# Altium schematic sheet MCU.SchDoc(MCU)
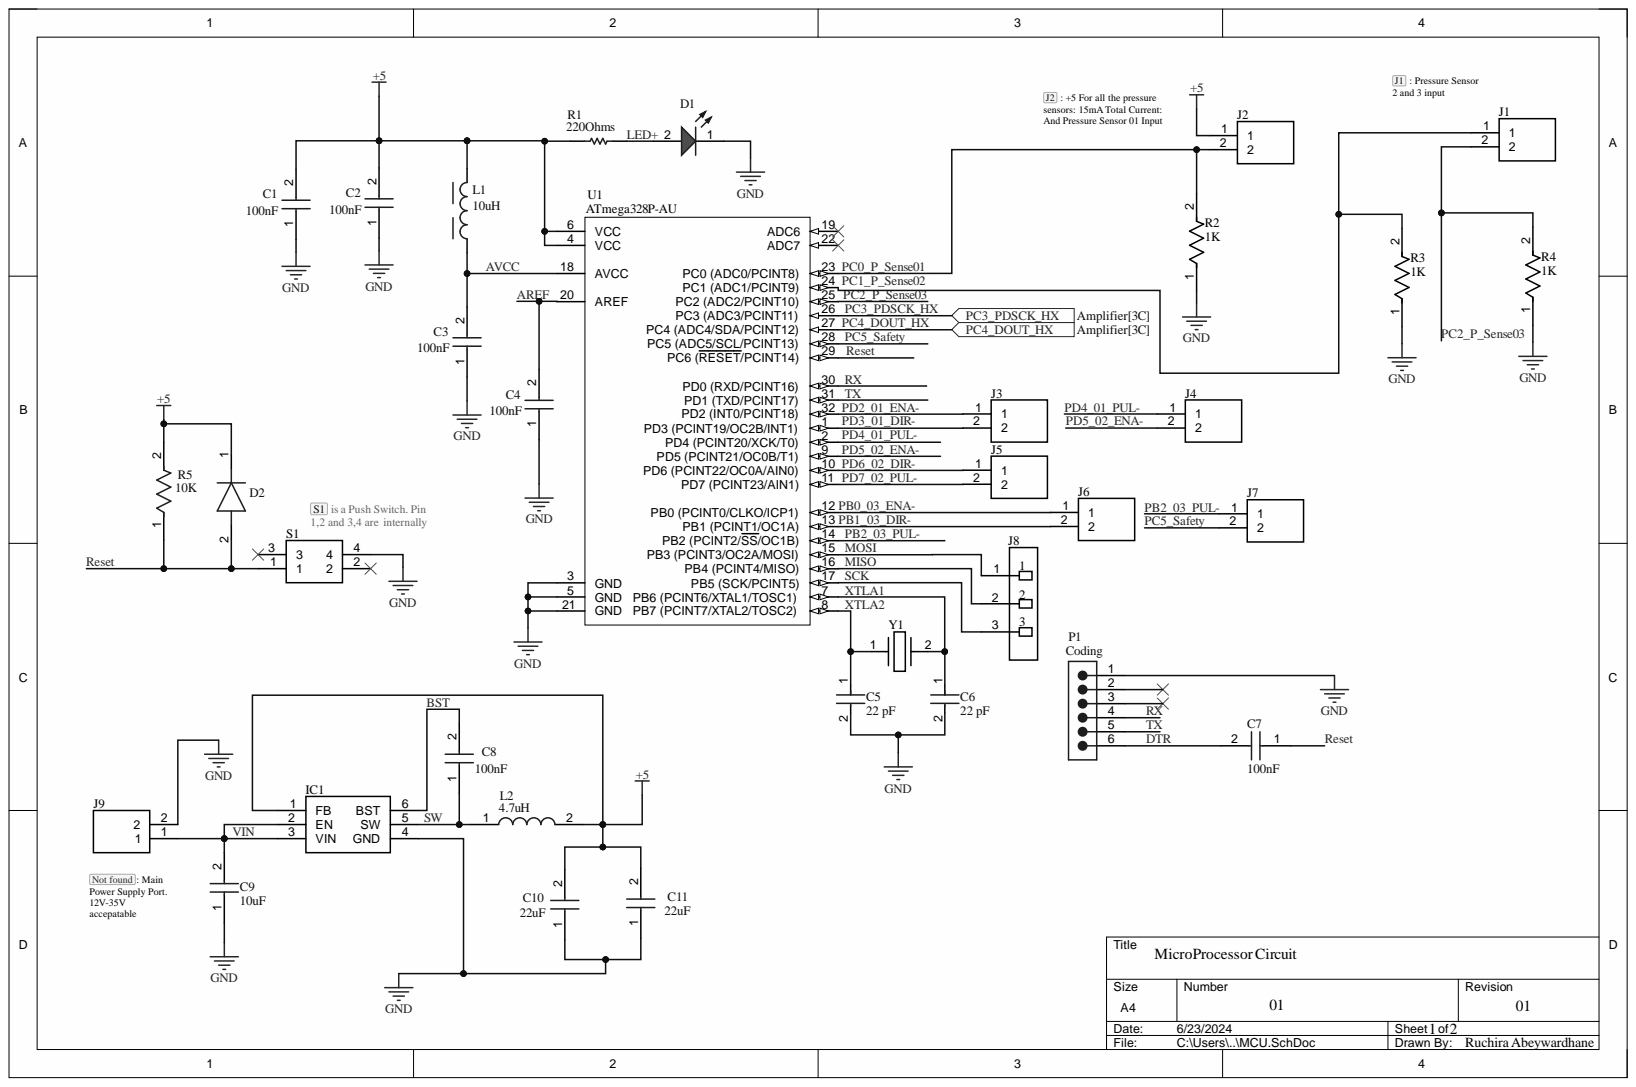
[diagram p0: MCU.SchDoc]

## Parts
C1  value 100nF  [C0805]  (hidden comment: C0805Y104J3RACTU)
C2  value 100nF  [C0805]  (hidden comment: C0805Y104J3RACTU)
C3  value 100nF  [C0805]  (hidden comment: C0805Y104J3RACTU)
C4  value 100nF  [C0805]  (hidden comment: C0805Y104J3RACTU)
C5  value 22 pF  Capacitance: 22 pF  [FP-C0805C-DN-MFG]  (hidden comment: C0805C220F1HACTU)
C6  value 22 pF  Capacitance: 22 pF  [FP-C0805C-DN-MFG]  (hidden comment: C0805C220F1HACTU)
C7  value 100nF  [C0805]  (hidden comment: C0805Y104J3RACTU)
C8  value 100nF  [C0805]  (hidden comment: C0805Y104J3RACTU)
C9  value 10uF  [C0805]  (hidden comment: C0805C106K4PAC7210)
C10  value 22uF  [C0805]  (hidden comment: C0805C226K9PACTU)
C11  value 22uF  [C0805]  (hidden comment: C0805C226K9PACTU)
D1  150080RS75000  [WL-SMCW_0805_150080xx75000]  (value not drawn)
D2  CDSU4148  [DIOM179X85N]  (value not drawn)
IC1  AP63205WU-7  [AP63205WU7]  (value not drawn)
J1  1727010  [1727010]  (value not drawn)
J2  1727010  [1727010]  (value not drawn)
J3  1727010  [1727010]  (value not drawn)
J4  1727010  [1727010]  (value not drawn)
J5  1727010  [1727010]  (value not drawn)
J6  1727010  [1727010]  (value not drawn)
J7  1727010  [1727010]  (value not drawn)
J8  TMM-103-03-T-S  [FP-TMM-103-03-T-S-MFG]  (value not drawn)
J9  1727010  [1727010]  (value not drawn)
L1  value 10uH  [WE-GFH_3225]  (hidden comment: 744764910)
L2  value 4.7uH  [INDPM7366X300N]  (hidden comment: SRP7028CC-4R7M)
P1  value Coding  [SMTC-TSW-106-07-X-S]  (hidden comment: TSW-106-07-L-S)
R1  value 220Ohms  [RESC2013X60X30ML20T20]  (hidden comment: RK73H2ATTD2200F)
R2  value 1K  [FP-RK73H2A-IPC_C]  (hidden comment: RK73H2ATTD1001D)
R3  value 1K  [FP-RK73H2A-IPC_C]  (hidden comment: RK73H2ATTD1001D)
R4  value 1K  [FP-RK73H2A-IPC_C]  (hidden comment: RK73H2ATTD1001D)
R5  value 10K  [FP-RK73H2A-IPC_C]  (hidden comment: RK73H2ATTED1002F)
S1  TL3305BF160QG  [TL3305BF160QG]  (value not drawn)
U1  ATmega328P-AU  [32A_M]
Y1  ABLS-16.000MHz-16-A-4-H-T  [ABLS]  (value not drawn)

## Ports
PC3_PDSCK_HX, PC4_DOUT_HX

## Nets
+5: C1.2 C2.2 C10.2 C11.2 D2.1 IC1.1(FB) J2.1 L1.2 L2.2 R1.1 R5.2 U1.4(VCC) U1.6(VCC)
GND: C1.1 C2.1 C3.1 C4.1 C5.2 C6.2 C9.1 C10.1 C11.1 D1.1 IC1.4(GND) J9.2 P1.1 R2.1 R3.1 R4.1 S1.4 U1.3(GND) U1.5(GND) U1.21(GND)
AREF: C4.2 U1.20(AREF)
AVCC: C3.2 L1.1 U1.18(AVCC)
BST: C8.2 IC1.6(BST)
DTR: C7.2 P1.6
LED+: D1.2 R1.2
MISO: J8.2 U1.16(PB4_(PCINT4/MISO))
MOSI: J8.1 U1.15(PB3_(PCINT3/OC2A/MOSI))
PB0_03_ENA-: J6.1 U1.12(PB0_(PCINT0/CLKO/ICP1))
PB1_03_DIR-: J6.2 U1.13(PB1_(PCINT1/OC1A))
PB2_03_PUL-: J7.1 U1.14(PB2_(PCINT2/SS/OC1B))
PC0_P_Sense01: J2.2 R2.2 U1.23
PC2_P_Sense03: J1.2 R4.2 U1.25
PC3_PDSCK_HX: U1.26(PC3_(ADC3/PCINT11)) ; port PC3_PDSCK_HX
PC4_DOUT_HX: U1.27(PC4_(ADC4/SDA/PCINT12)) ; port PC4_DOUT_HX
PC5_Safety: J7.2 U1.28(PC5_(ADC5/SCL/PCINT13))
PD2_01_ENA-: J3.1 U1.32(PD2_(INT0/PCINT18))
PD3_01_DIR-: J3.2 U1.1(PD3_(PCINT19/OC2B/INT1))
PD4_01_PUL-: J4.1 U1.2(PD4_(PCINT20/XCK/T0))
PD5_02_ENA-: J4.2 U1.9(PD5_(PCINT21/OC0B/T1))
PD6_02_DIR-: J5.1 U1.10(PD6_(PCINT22/OC0A/AIN0))
PD7_02_PUL-: J5.2 U1.11(PD7_(PCINT23/AIN1))
Reset: C7.1 D2.2 R5.1 S1.1 U1.29(PC6_(RESET/PCINT14))
RX: P1.4 U1.30(PD0_(RXD/PCINT16))
SCK: J8.3 U1.17(PB5_(SCK/PCINT5))
SW: C8.1 IC1.5(SW) L2.1
TX: P1.5 U1.31(PD1_(TXD/PCINT17))
VIN: C9.2 IC1.2(EN) IC1.3(VIN) J9.1
XTLA1: C6.1 U1.7 Y1.2
XTLA2: C5.1 U1.8 Y1.1
NetJ1_1: J1.1 R3.2 U1.24(PC1_(ADC1/PCINT9))
PC1_P_Sense02:

Not connected: P1 2 3; S1 2 3; U1 19(ADC6) 22(ADC7)

## Library data of the parts
C1-C4 C7 C8 = C0805Y104J3RACTU: Capacitor; Manufacturer_Name: KEMET; Component Kind: Standard; Datasheet Link: https://content.kemet.com/datasheets/KEM_C1015_X7R_FF-CAP_SMD.pdf; Height: 1.1mm; Manufacturer_Part_Number: C0805Y104J3RACTU
C5 C6 = CMP-03020-009085-1: C0805 22 pF X8R 30ppm/°C 1.00% 100 V; Manufacturer: KEMET; Material: Ceramic; Tolerance: 0.01; Voltage Rating: 100 V; Automotive: No; Category: Cap; Component Kind: Standard; ComponentLink2Description: Datasheet; ComponentLink2URL: https://content.kemet.com/datasheets/KEM_C1003_C0G_SMD.pdf; Device Class L1: Passive Components; Device Class L2: Capacitors; Device Class L3: Ceramic Capacitors; Height: 0.88mm; Ipc Land Pattern Name: CAPC200125X78; Lead Free: Yes; Library Name: Altium Content Vault; Manufacturer Part Number: C0805C220F1HACTU; Octopart: https://octopart.com/c0805c220f1hactu-kemet-88726806; Package: C0805C-DN; RoHS: TRUE; Temperature: -55°C to +125°C; Temperature Characteristic: X8R; Temperature Coefficient: 30ppm/°C; Temperature Range High: +125°C; Temperature Range Low: -55°C
C9 = C0805C106K4PAC7210: Capacitor; Manufacturer_Name: KEMET; Arrow Part Number: C0805C106K4PAC7210; Arrow Price/Stock: https://www.arrow.com/en/products/c0805c106k4pac7210/kemet-corporation; Component Kind: Standard; Datasheet Link: https://content.kemet.com/datasheets/KEM_C1006_X5R_SMD.pdf; Height: 1.1mm; Manufacturer_Part_Number: C0805C106K4PAC7210; Mouser Part Number: 80-C0805C106K4P7210; Mouser Price/Stock: https://www.mouser.co.uk/ProductDetail/KEMET/C0805C106K4PAC7210?qs=zDkSFN9STR9jrJZBgSwCDA%3D%3D
C10 C11 = C0805C226K9PACTU: Capacitor; Manufacturer_Name: KEMET; Arrow Part Number: C0805C226K9PACTU; Arrow Price/Stock: https://www.arrow.com/en/products/c0805c226k9pactu/kemet-corporation; Component Kind: Standard; Datasheet Link: https://content.kemet.com/datasheets/KEM_C1006_X5R_SMD.pdf; Height: 1.1mm; Manufacturer_Part_Number: C0805C226K9PACTU; Mouser Part Number: 80-C0805C226K9PACTU; Mouser Price/Stock: https://www.mouser.co.uk/ProductDetail/KEMET/C0805C226K9PACTU?qs=CmJlwSR1kv6i2XEByn7wWw%3D%3D
D1 = CMP-1426-00011-2: NexarPartId: 29717781; Color: Red; Dominant Wavelength: 625nm; Forward Current: 100mA; Forward Voltage: 2V; Lens Style: Clear, Rectangular; Luminous Intensity: 150mcd; Max Reverse Voltage (DC): 5V; Peak Wavelength: 630nm; Test Current: 20mA; Viewing Angle: 140°; Case Code (Imperial): 0805; Case Code (Metric): 2012; Case/Package: 0805; Component Kind: Standard; Height: 700µm; Length: 2mm; Library Name: Altium Content Vault; Max Operating Temperature: 85°C; Min Operating Temperature: -40°C; Mount: Surface Mount; Packaging: Tape and Reel; REACH SVHC: Unknown; RoHS: Compliant; Wavelength: 625nm; Width: 1.25mm
D2 = CDSU4148: Diode; Manufacturer_Name: Comchip Technology; Arrow Part Number: CDSU4148; Arrow Price/Stock: https://www.arrow.com/en/products/cdsu4148/comchip-technology?region=nac; Component Kind: Standard; Datasheet Link: https://datasheet.datasheetarchive.com/originals/distributors/Datasheets-7/DSA-139250.pdf; Height: 0.85mm; Manufacturer_Part_Number: CDSU4148; Mouser Part Number: 750-CDSU4148; Mouser Price/Stock: https://www.mouser.co.uk/ProductDetail/Comchip-Technology/CDSU4148?qs=tw%252BuQ%2FB6PO1A4Pq3N4jPEA%3D%3D
IC1 = AP63205WU-7: Integrated Circuit; Manufacturer_Name: Diodes Incorporated; Arrow Part Number: AP63205WU-7; Arrow Price/Stock: https://www.arrow.com/en/products/ap63205wu-7/diodes-incorporated?region=europe; Component Kind: Standard; Datasheet Link: https://www.diodes.com//assets/Datasheets/AP63200-AP63201-AP63203-AP63205.pdf; Height: 1mm; Library Name: SamacSys.SchLib; Manufacturer_Part_Number: AP63205WU-7; Mouser Part Number: 621-AP63205WU-7; Mouser Price/Stock: https://www.mouser.co.uk/ProductDetail/Diodes-Incorporated/AP63205WU-7?qs=u16ybLDytRZtkj8PzdWCOw%3D%3D
J1-J7 J9 = 1727010: Connector; Manufacturer_Name: Phoenix Contact; Arrow Part Number: 1727010; Arrow Price/Stock: https://www.arrow.com/en/products/1727010/phoenix-contact?region=nac; Component Kind: Standard; Datasheet Link: https://datasheet.datasheetarchive.com/originals/distributors/SFDatasheet-2/sf-00050638.pdf; Height: 8.65mm; Manufacturer_Part_Number: 1727010; Mouser Part Number: 651-1727010; Mouser Price/Stock: https://www.mouser.co.uk/ProductDetail/Phoenix-Contact/1727010?qs=JcjeNNoqGSMjtHhQoBJ7UA%3D%3D
J8 = CMP-02766-001695-1: CONN HEADER VERT 3POS 2MM; Gender: Male; Max Current Rating: 3.9A; Max Voltage Rating (AC): 500V; Number of Contacts: 3; Color: Black; Component Kind: Standard; Connector Type: Header; Contact Finish - Mating: TIN; Contact Material: Bronze; Contact Mating Length: 0.157inch; Contact Plating: Tin; Contact Shape: Square; Contact Type: Male Pin; Depth: 1.97mm; Fastening Type: Pull; Housing Color: Black; Housing Material: Polymer; Insulation Color: Black; Insulation Material: Polymer; Lead Free: Lead Free; Length: 6mm; Library Name: Altium Content Vault; Max Operating Temperature: 105°C; Min Operating Temperature: -55°C; Mount: Through Hole; Number of Positions: 3; Number of Rows: 1; Orientation: Straight; Package Quantity: 1; Packaging: Bulk; Pitch: 2mm; Radiation Hardening: No; REACH SVHC: No SVHC; RoHS Compliant: Yes; Series: TMM; Termination: Solder
L1 = CMP-03913-61645378-1: 744764910 General Purpose Inductor, 10uH, 10%, 1 Element, SMD, 1210; Core Material: Ferrite; Current Rating: 500mA; DC Resistance (DCR): 995mΩ; Inductance: 10µH; Self Resonant Frequency: 40MHz; Shielding: Unshielded; Test Frequency: 1.47MHz; Ambient Temperature Range High: 85°C; Ambient Temperature Range Low: -40°C; Case/Package: Nonstandard; Component Kind: Standard; Height: 2.2mm; Height - Seated (Max): 2.3876mm; Inductance Tolerance: 10%; Length: 3.2mm; Library Name: Altium Content Vault; Max DC Current: 500mA; Max Operating Temperature: 125°C; Min Operating Temperature: -40°C; Mount: Surface Mount; NexarPartId: 61645378; Packaging: Tape & Reel (TR); REACH SVHC: Unknown; RoHS: Compliant; Tolerance: 10%; Width: 2.5mm
L2 = SRP7028CC-4R7M: Inductor; Manufacturer_Name: Bourns; Component Kind: Standard; Datasheet Link: https://www.bourns.com/docs/Product-Datasheets/SRP7028CC.pdf; Height: 3mm; Manufacturer_Part_Number: SRP7028CC-4R7M; Mouser Part Number: 652-SRP7028CC-4R7M; Mouser Price/Stock: https://www.mouser.co.uk/ProductDetail/Bourns/SRP7028CC-4R7M?qs=1Kr7Jg1SGW%252BnpjZpZxrorQ%3D%3D
P1 = CMP-1024-00386-1: 0.025" SQ Post Header, Through-hole, Vertical, -55 to 125 degC, 2.54 mm Pitch, 6-Pin, Male, RoHS; Manufacturer: Samtec; Pitch: 2.54 mm; Component Kind: Standard; ComponentLink1Description: Manufacturer URL; ComponentLink1URL: http://www.samtec.com; ComponentLink2Description: Datasheet; ComponentLink2URL: http://www.samtec.com/documents/webfiles/pdf/TSW_TH.PDF; ComponentLink3Description: Package Specification; ComponentLink3URL: http://www.samtec.com/documents/webfiles/cpdf/TSW-XXX-XX-XXX-X-XX-XXX-MKT.pdf; DatasheetVersion: F-214; Library Name: Altium Content Vault; Mounting Technology: Through-hole; Orientation: Vertical; PackageDescription: .025[0.64] SQ. Post Terminal Strip Assembly; PackageReference: TSW-106-07-X-S; PackageVersion: DM, 8/1988; PartNumber: TSW-106-07-L-S; RoHS: True; Temperature Range: -55 to 125 degC
R1 = CMP-2001-02206-1: Manufacturer: KOA Speer; Component Kind: Standard; Library Name: Altium Content Vault; Part Number: RK73H2ATTD2200F
R2-R4 = CMP-04015-089471-1: 1kΩ ±0.5% 0.25W Chip Resistor 0805 Thick Film AEC-Q200 Qualified; Component Kind: Standard; Library Name: Altium Content Vault; RoHS Compliant: Yes
R5 = CMP-04015-088604-1: 10kΩ ±1% 0.25W Chip Resistor 0805 Thick Film AEC-Q200 Qualified; Power Rating: 125mW; Resistance: 10kR; Temperature Coefficient: 100ppm/°C; Voltage Rating: 150V; Case Code (Imperial): 0805; Case Code (Metric): 2012; Case/Package: 0805; Component Kind: Standard; Construction: Rectangular; Depth: 1.25mm; Height: 0.5mm; Lead Free: Lead Free; Length: 2mm; Library Name: Altium Content Vault; Max Operating Temperature: 155°C; Military Standard: Not; Min Operating Temperature: -55°C; Package Quantity: 10000; Packaging: Tape and Reel; Resistor Type: Thick Film; RoHS Compliant: Yes; Series: RK73H; Termination: SMD/SMT; Tolerance: 1%; Width: 1.25mm
S1 = TL3305BF160QG: Switch; Manufacturer_Name: E-Switch; Component Kind: Standard; Datasheet Link: https://configured-product-images.s3.amazonaws.com/2D/specs/TL3305BF160QG.pdf; Height: 5.25mm; Manufacturer_Part_Number: TL3305BF160QG; Mouser Part Number: 612-TL3305BF160QG; Mouser Price/Stock: https://www.mouser.co.uk/ProductDetail/E-Switch/TL3305BF160QG?qs=UrFqKgNWc7SMzrRgAbHJ9w%3D%3D
U1 = CMP-0095-00269-2: 8-bit AVR Microcontroller, 32KB Flash, 1KB EEPROM, 2KB SRAM, 32-pin TQFP, Industrial Grade (-40°C to 85°C); Code_JEDEC: MS-026-ABA; Component Kind: Standard; ComponentLink1Description: Manufacturer URL; ComponentLink1URL: http://www.atmel.com/; ComponentLink2Description: ATmega48A/48PA/88A/88PA/168A/168PA/328/328P Datasheet; ComponentLink2URL: http://www.atmel.com/dyn/resources/prod_documents/doc8271.pdf; ComponentLink3Description: ATmega48A/48PA/88A/88PA/168A/168PA/328/328P Summary; ComponentLink3URL: http://www.atmel.com/dyn/resources/prod_documents/8271S.pdf; DatasheetVersion: revD, May-2011; Library Name: Altium Content Vault; PackageDescription: 32-Lead, 7x7x1.0 mm Body, 0.8mm Lead Pitch, Thin Profile Plastic Quad Flat Package (TQFP); PackageReference: 32A; PackageVersion: revC, Oct-2010; RevisionNote: DatasheetVersion & PackageVersion updated. URLs added.
Y1 = ABLS-16.000MHz-16-A-4-H-T: Crystal or Oscillator; Manufacturer_Name: ABRACON; Arrow Part Number: ABLS-16.000MHZ-16-A-4-H-T; Arrow Price/Stock: https://www.arrow.com/en/products/abls-16.000mhz-16-a-4-h-t/abracon; Component Kind: Standard; Datasheet Link: https://abracon.com/Resonators/abls.pdf; Library Name: SamacSys.SchLib; Manufacturer_Part_Number: ABLS-16.000MHz-16-A-4-H-T; Mouser Part Number: 815-LS16000MHZ16A4HT; Mouser Price/Stock: https://www.mouser.co.uk/ProductDetail/ABRACON/ABLS-16.000MHZ-16-A-4-H-T?qs=vLWxofP3U2z6hhU1at4Vrw%3D%3D
